# Altium schematic sheet Debug_USB.SchDoc
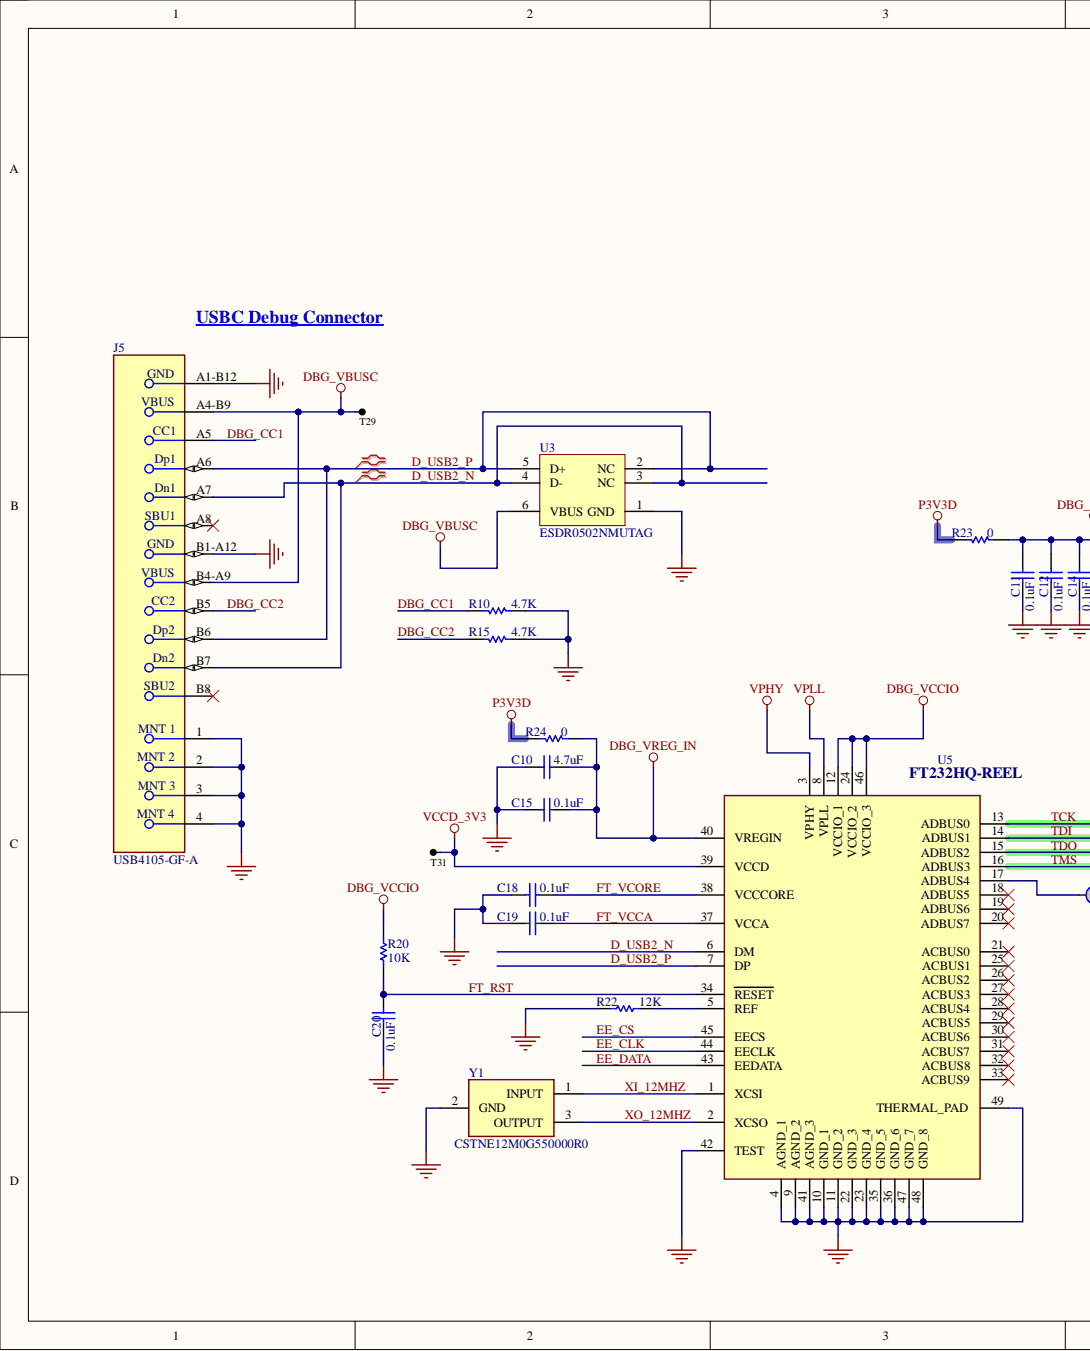
[diagram p0: Debug_USB.SchDoc, left]
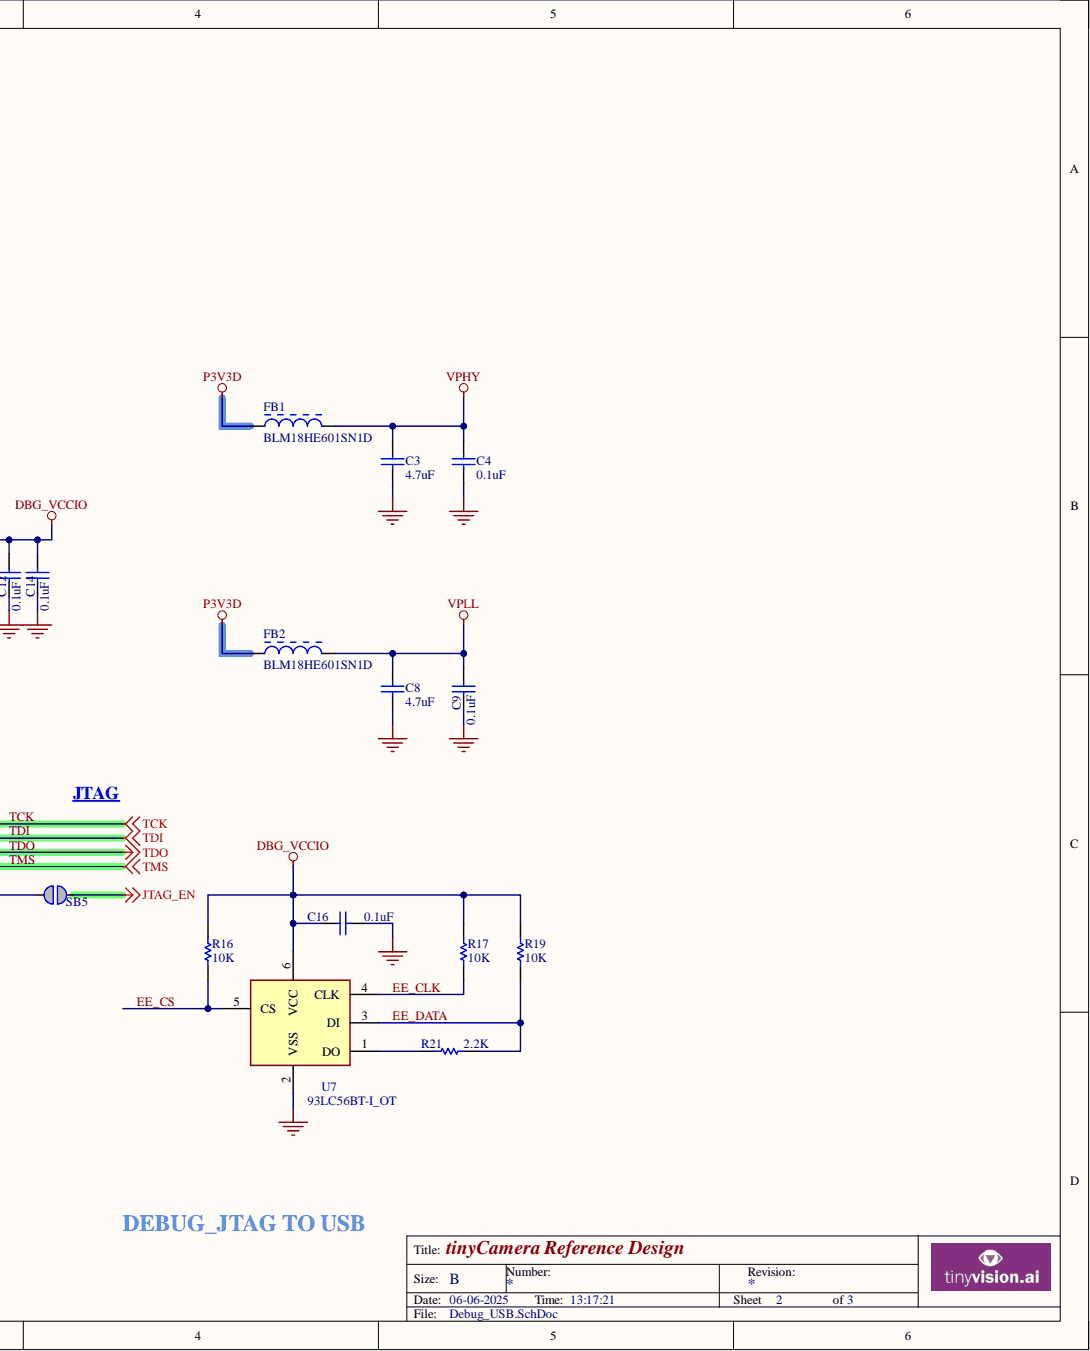
[diagram p1: Debug_USB.SchDoc, right]

## Parts
C3  4.7uF  [CAPC1005X65USR]
C4  0.1uF  [CAPC0603X33N]
C8  4.7uF  [CAPC1005X65USR]
C9  0.1uF  [CAPC0603X33N]
C10  4.7uF  [CAPC1005X65USR]
C11  0.1uF  [CAPC0603X33N]
C12  0.1uF  [CAPC0603X33N]
C14  0.1uF  [CAPC0603X33N]
C15  0.1uF  [CAPC0603X33N]
C16  0.1uF  [CAPC0603X33N]
C18  0.1uF  [CAPC0603X33N]
C19  0.1uF  [CAPC0603X33N]
C20  0.1uF  [CAPC0603X33N]
FB1  BLM18HE601SN1D  [0603_FB]
FB2  BLM18HE601SN1D  [0603_FB]
J5  USB4105-GF-A  [USB4105_GCT]
R10  4.7K  [RESC1005X40USR]
R15  4.7K  [RESC1005X40USR]
R16  10K  [RESC1005X40USR]
R17  10K  [RESC1005X40USR]
R19  10K  [RESC1005X40USR]
R20  10K  [RESC1005X40USR]
R21  2.2K  [RESC1005X40USR]
R22  12K  [RESC1005X40USR]
R23  0  [RESC1005X40USR]
R24  0  [RESC1005X40USR]
SB5  Solderbridge  [Solderbridge]  (value not drawn)  (no BOM)
T29  TP_S  [TP 20mil]  (value not drawn)  (no BOM)
T31  TP_S  [TP 20mil]  (value not drawn)  (no BOM)
U3  ESDR0502NMUTAG  [ONSC-UDFN-6-517AA-01_V]
U5  FT232HQ-REEL  [QFN50P800X800X100-49N-D]
U7  93LC56BT-I_OT  [SOT95P270X145-6N]
Y1  CSTNE12M0G550000R0  [OSC_CSTNE12M0G550000R0]

## Nets
DBG_VBUSC: J5.A4-B9 J5.B4-A9 T29.1 U3.6(VBUS)
DBG_VCCIO: C11.2 C12.2 C14.2 C16.2 R16.1 R17.1 R19.1 R20.2 R23.1 U5.12(VCCIO_1) U5.24(VCCIO_2) U5.46(VCCIO_3) U7.6(VCC)
DBG_VREG_IN: C10.2 C15.2 R24.1 U5.40(VREGIN)
GND: C3.1 C4.1 C8.1 C9.1 C10.1 C11.1 C12.1 C14.1 C15.1 C16.1 C18.1 C19.1 C20.1 J5.1 J5.2 J5.3 J5.4 J5.A1-B12 J5.B1-A12 R10.1 R15.1 R22.1 U3.1(GND) U5.4(AGND_1) U5.9(AGND_2) U5.10(GND_1) U5.11(GND_2) U5.22(GND_3) U5.23(GND_4) U5.35(GND_5) U5.36(GND_6) U5.41(AGND_3) U5.42(TEST) U5.47(GND_7) U5.48(GND_8) U5.49(THERMAL_PAD) U7.2(VSS) Y1.2
JTAG_EN: SB5.1
P3V3D: FB1.2 FB2.2 R23.2 R24.2
VCCD_3V3: T31.1 U5.39(VCCD)
VPHY: C3.2 C4.2 FB1.1 U5.3(VPHY)
VPLL: C8.2 C9.2 FB2.1 U5.8(VPLL)
D_USB2_N: J5.A7 J5.B7 U3.3(NC) U3.4(D-) U5.6(DM)
D_USB2_P: J5.A6 J5.B6 U3.2(NC) U3.5(D+) U5.7(DP)
DBG_CC1: J5.A5 R10.2
DBG_CC2: J5.B5 R15.2
EE_CLK: R17.2 U5.44(EECLK) U7.4(CLK)
EE_CS: R16.2 U5.45(EECS) U7.5(CS)
EE_DATA: R19.2 R21.2 U5.43(EEDATA) U7.3(DI)
FT_RST: C20.2 R20.1 U5.34(RESET)
FT_VCCA: C19.2 U5.37(VCCA)
FT_VCORE: C18.2 U5.38(VCCCORE)
TCK: U5.13(ADBUS0)
TDI: U5.14(ADBUS1)
TDO: U5.15(ADBUS2)
TMS: U5.16(ADBUS3)
XI_12MHZ: U5.1(XCSI) Y1.1
XO_12MHZ: U5.2(XCSO) Y1.3
NetR21_1: R21.1 U7.1(DO)
NetR22_2: R22.2 U5.5(REF)
NetSB5_2: SB5.2 U5.17(ADBUS4)

Not connected: J5 A8 B8; U5 18(ADBUS5) 19(ADBUS6) 20(ADBUS7) 21(ACBUS0) 25(ACBUS1) 26(ACBUS2) 27(ACBUS3) 28(ACBUS4) 29(ACBUS5) 30(ACBUS6) 31(ACBUS7) 32(ACBUS8) 33(ACBUS9)

## Library data of the parts
C3 C8 C10 = CAP CER 4.7UF 10V X5R 0402: Manufacturer: TDK Corporation; Manufacturer Part Number: C1005X5R1A475M050BC; Component Kind: Standard; Description: CAP CER 4.7UF 10V X5R 0402; Library Name: tinyAI.SCHLIB; Package: 0402; Supplier 1: Digi-Key; Supplier Part Number 1: 445-8023-1-ND; value: 4.7uF
C4 C9 C11 C12 C14-C16 C18-C20 = CAP CER 0.1UF 16V X7S 0201: Manufacturer: Murata Electronics; Manufacturer Part Number: GRM033C71C104KE14D; Component Kind: Standard; Description: CAP CER 0.1UF 16V X7S 0201; Height: 0.33mm; Library Name: tinyAI.SCHLIB; Package: 0201; Supplier 1: Digi-Key; Supplier Part Number 1: 490-10387-1-ND; value: 0.1uF
FB1 FB2 = MURA-FB-2: FERRITE BEAD 600 OHM 0603 1LN; Manufacturer: Murata Electronics; Value: 120Ohms; Component Kind: Standard; ComponentLink1Description: New link; Height: 0.55mm; Library Name: NX Module.SCHLIB; Manufacturer Part Number: BLM18HE601SN1D; Package: 0603; Supplier 1: Digi-Key; Supplier Part Number 1: 490-5214-1-ND
J5 = CONN RCP USB2.0 TYP C 24P SMD RA: Conn USB 2.0 Type C RCP 24 POS 0.3mm/0.5mm Solder RA SMD 24 Terminal 1 Port T/R; Manufacturer: Global Connector Technology; Category: USB Connectors; Component Kind: Standard; Manufacturer Part Number: USB4105-GF-A; Pricing 1: 1=0.75400, 10=0.68200, 25=0.64000, 50=0.61200, 100=0.58400, 250=0.52900, 500=0.48700 (USD); RoHS: No; Stock 1: 2162; Supplier 1: Newark; Supplier Part Number 1: 86AH2021; Library Name: Tiger_Ti180.SCHLIB
R10 R15 = RES 4.7K OHM 1% 1/16W 0402: RES SMD 4.7K OHM 1% 1/16W 0402; Manufacturer: Stackpole Electronics Inc; Manufacturer Part Number: RMCF0402FT4K70; Component Kind: Standard; Height: 0.4mm; Package: 0402; Supplier 1: Digi-Key; Supplier Part Number 1: RMCF0402FT4K70TR-ND; value: 4.7K; Library Name: Tiger_Ti180.SCHLIB
R16 R17 R19 R20 R22 = RES SMD 10K OHM 1% 1/16W 0402: Manufacturer: Samsung Electro-Mechanics; Manufacturer Part Number: RC1005F103CS; Component Kind: Standard; Description: RES SMD 10K OHM 1% 1/16W 0402; Height: 0.4mm; Library Name: tinyCamera.SCHLIB; Package: 0402; Supplier 1: Digi-Key; Supplier Part Number 1: 1276-3431-2-ND; value: 10K
R21 = RES 2K OHM 1% 1/16W 0402: RES 2.2K OHM 1% 1/16W 0402; Manufacturer: YAGEO; Manufacturer Part Number: RC0402FR-072KL; value: 2K; Component Kind: Standard; Height: 0.4mm; Library Name: tinyAI.SCHLIB; Package: 0402; Supplier 1: Digi-Key; Supplier Part Number 1: 311-2KLRCT-ND
R23 R24 = RES 0.5 OHM 1% 1/8W 0402: RES 0 OHM JUMPER 1/16W 0402; Manufacturer: Stackpole Electronics Inc; Manufacturer Part Number: RC0402JR-070RL; Component Kind: Standard; Height: 0.43mm; Library Name: tinyAI.SCHLIB; Package: 0402; Supplier 1: Digi-Key; value: 0
SB5 = Solderbridge: Artikkelnr Noca: N.A.; Artikkelnr Simpro: N.A.; Description: Solderbridge; Footprint Doc: Solderbridge; LatestRevisionDate: 2009-12-14; Library Name: AiC.SCHLIB; Part Number: Solderbridge; Pin Count: 2; Published: 2009-12-14; Value: Solderbridge; Publisher: Nordic Semiconductor
T29 T31 = TP_S: test point; Library Name: AiC RVP Adapter.SCHLIB
U3 = ONSC-ESDR0502N-UDFN-6-517AA-01: TVS DIODE 5.5VWM 6UDFN; Manufacturer: ON Semiconductor; Component Kind: Standard; ComponentLink1Description: Manufacturer URL; ComponentLink1URL: http://www.onsemi.com/; ComponentLink2Description: Datasheet; ComponentLink2URL: http://www.onsemi.cn/pub_link/Collateral/ESDR0502N-D.PDF; ComponentLink3Description: Package Specification; ComponentLink3URL: http://www.onsemi.com/pub_link/Collateral/517AA.PDF; Manufacturer Part Number: ESDR0502NMUTAG; Supplier 1: Mouser; Supplier Part Number 1: 863-ESDR0502NMUTAG; Library Name: Tiger_Ti180.SCHLIB
U5 = FT232HQ-REEL: USB 2.0 to UART/FIFO Interface, QFN48 FTDI Chip FT232HQ-REEL Universal Asynchronous Receiver & Transmitter RS232, RS422, RS485 UART 12Mbit/s, 1.8 V, 3.3 V; Geometry.Height: 1mm; Arrow Part Number: FT232HQ-REEL; Arrow Price/Stock: https://www.arrow.com/en/products/ft232hq-reel/ftdi-chip?utm_currency=USD&region=nac; Component Kind: Standard; Datasheet Link: http://www.ftdichip.com/Support/Documents/DataSheets/ICs/DS_FT232H.pdf; Library Name: Altium_Lib.IntLib; Manufacturer_Name: FTDI Chip; Manufacturer_Part_Number: FT232HQ-REEL
U7 = 93LC56BT-I_OT: 2K,128 X 16,Serial EE,SOT23; Manufacturer_Name: Microchip; Manufacturer_Part_Number: 93LC56BT-I/OT; Geometry.Height: 1.45mm; Arrow Part Number: 93LC56BT-I/OT; Arrow Price/Stock: https://www.arrow.com/en/products/93lc56bt-iot/microchip-technology?region=nac; Component Kind: Standard; Datasheet Link: http://ww1.microchip.com/downloads/en/DeviceDoc/21794G.pdf; Library Name: Altium_Lib.IntLib
Y1 = CSTNE12M0G550000R0: CERAMIC RES 12.0000MHZ 33PF SMD; Manufacturer: Murata Electronics; Component Kind: Standard; Datasheet Link: https://www.murata.com/en-eu/products/productdata/8801162067998/SPEC-CSTNE12M0G550000R0.pdf?1559743212000; Height: 0.8mm; Library Name: AiC.SCHLIB; Manufacturer Part Number: CSTNE12M0G550000R0; Supplier 1: Mouser; Supplier Part Number 1: 81-CSTNE12M0G550000R
